# Altium schematic sheet MCU_AND_PW4.SchDoc
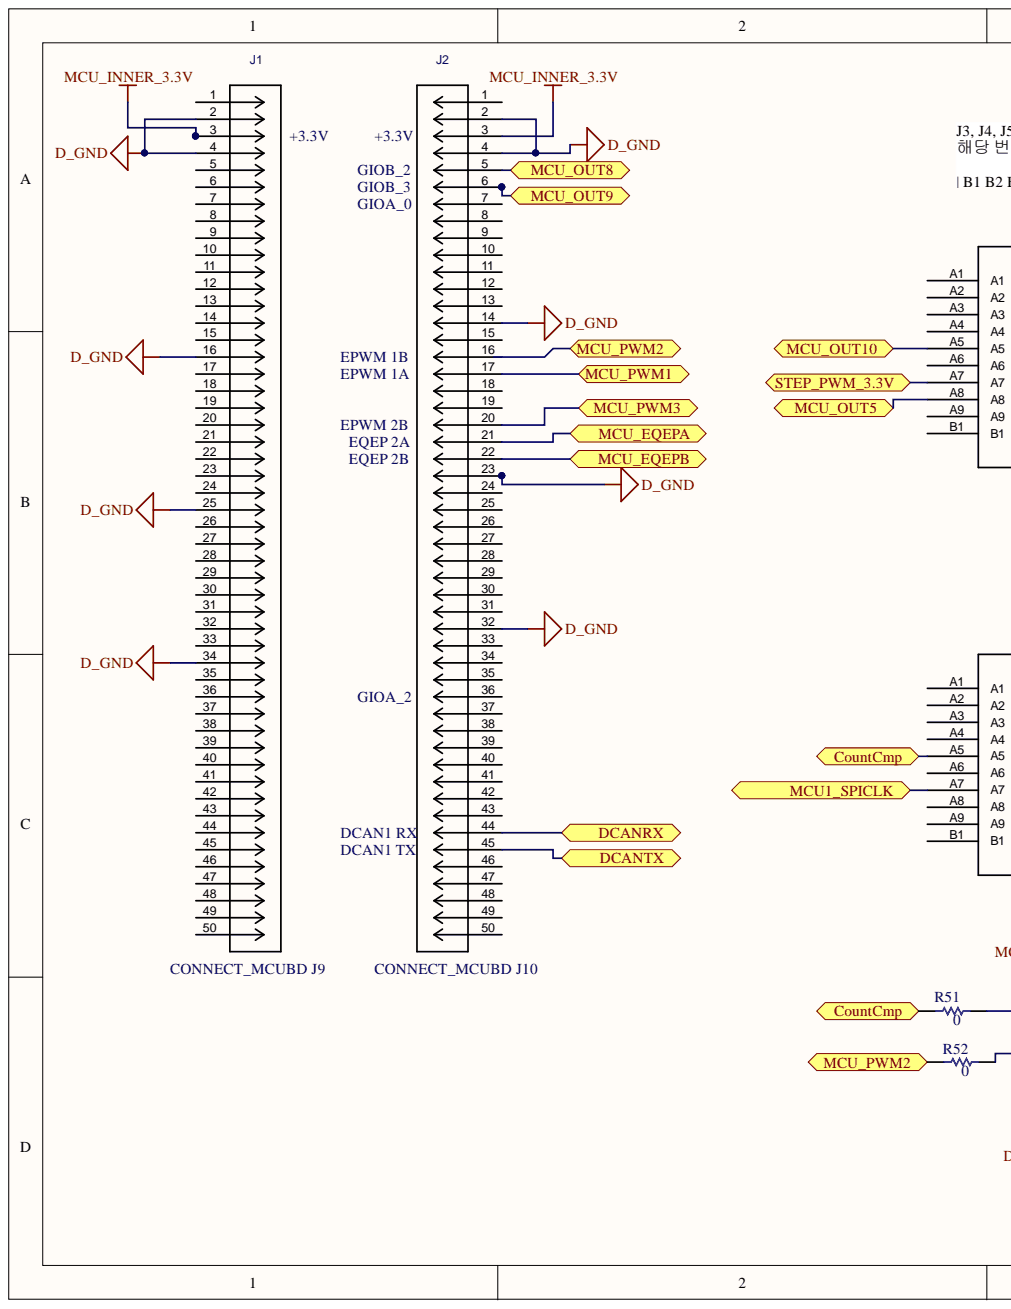
[diagram p0: MCU_AND_PW4.SchDoc, left]
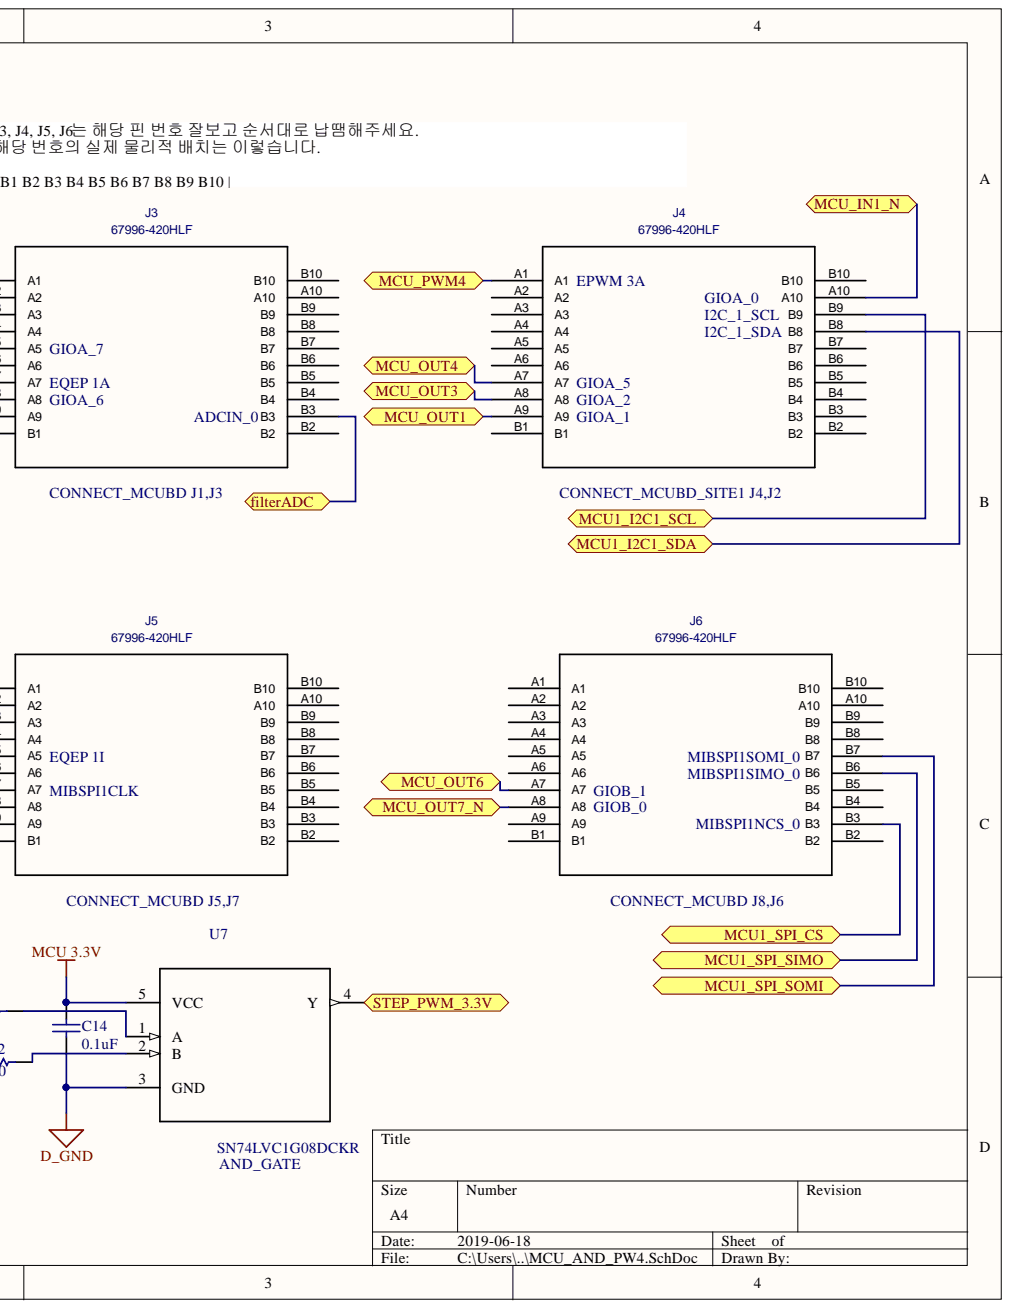
[diagram p1: MCU_AND_PW4.SchDoc, right]

## Parts
C14  value 0.1uF  [RAD-0.3]  (hidden comment: Cap)
J1  SLW-150-01-G-S  [CON50_1X50_TU_SL]  (value not drawn)
J2  SLW-150-01-G-S  [CON50_1X50_TU_SL]  (value not drawn)
J3  67996-420HLF  [67996-420HLF_67996-420HLF(Primary)]
J4  67996-420HLF  [67996-420HLF_67996-420HLF(Primary)]
J5  67996-420HLF  [67996-420HLF_67996-420HLF(Primary)]
J6  67996-420HLF  [67996-420HLF_67996-420HLF(Primary)]
R51  value 0  [AXIAL-0.3]  (hidden comment: Res1)
R52  value 0  [AXIAL-0.3]  (hidden comment: Res1)
U7  SN74LVC1G08DCKR  [*SOT65P210X110-5N]

## Ports
COUNTCMP, DCANRX, DCANTX, FILTERADC, MCU1_I2C1_SCL, MCU1_I2C1_SDA, MCU1_SPI_CS, MCU1_SPI_SIMO, MCU1_SPI_SOMI, MCU1_SPICLK, MCU_EQEPA, MCU_EQEPB, MCU_IN1_N, MCU_OUT1, MCU_OUT3, MCU_OUT4, MCU_OUT5, MCU_OUT6, MCU_OUT7_N, MCU_OUT8, MCU_OUT9, MCU_OUT10, MCU_PWM1, MCU_PWM2, MCU_PWM3, MCU_PWM4, STEP_PWM_3.3V

## Nets
D_GND: C14.2 J1.2 J1.4 J1.16 J2.23 U7.3(GND)
MCU 3.3V: C14.1 U7.5(VCC)
MCU_INNER_3.3V: J1.3
MCU1_I2C1_SCL: J4.B9 ; port MCU1_I2C1_SCL
MCU1_I2C1_SDA: J4.B8 ; port MCU1_I2C1_SDA
MCU1_SPI_CS: J6.B3 ; port MCU1_SPI_CS
MCU1_SPI_SIMO: J6.B6 ; port MCU1_SPI_SIMO
MCU1_SPI_SOMI: J6.B7 ; port MCU1_SPI_SOMI
MCU1_SPICLK: J5.A7 ; port MCU1_SPICLK
MCU_IN1_N: J4.A10 ; port MCU_IN1_N
MCU_OUT1: J4.A9 ; port MCU_OUT1
MCU_OUT3: J4.A8 ; port MCU_OUT3
MCU_OUT4: J4.A7 ; port MCU_OUT4
MCU_OUT5: J3.A8 ; port MCU_OUT5
MCU_OUT6: J6.A7 ; port MCU_OUT6
MCU_OUT7_N: J6.A8 ; port MCU_OUT7_N
MCU_OUT9: J2.6 ; port MCU_OUT9
MCU_OUT10: J3.A5 ; port MCU_OUT10
MCU_PWM2: R52.1 ; port MCU_PWM2
MCU_PWM4: J4.A1 ; port MCU_PWM4
STEP_PWM_3.3V: J3.A7 U7.4(Y) ; port STEP_PWM_3.3V
NetJ3_B3: J3.B3 ; port FILTERADC
NetJ5_A5: J5.A5 R51.1 ; port COUNTCMP
NetR51_2: R51.2 U7.1(A)
NetR52_2: R52.2 U7.2(B)
N00133: ; port MCU_PWM3
N00134: ; port MCU_PWM1
N00135: ; port MCU_EQEPB
N00136: ; port MCU_EQEPA
N00138: ; port DCANTX
N00139: ; port DCANRX
N00140: ; port MCU_OUT8

Not connected: J1 1 5 6 7 8 9 10 11 12 13 14 15 17 18 19 20 21 22 23 24 25 26 27 28 29 30 31 32 33 34 35 36 37 38 39 40 41 42 43 44 45 46 47 48 49 50; J2 1 2 3 4 5 7 8 9 10 11 12 13 14 15 16 17 18 19 20 21 22 24 25 26 27 28 29 30 31 32 33 34 35 36 37 38 39 40 41 42 43 44 45 46 47 48 49 50; J3 A1 A2 A3 A4 A6 A9 A10 B1 B2 B4 B5 B6 B7 B8 B9 B10; J4 A2 A3 A4 A5 A6 B1 B2 B3 B4 B5 B6 B7 B10; J5 A1 A2 A3 A4 A6 A8 A9 A10 B1 B2 B3 B4 B5 B6 B7 B8 B9 B10; J6 A1 A2 A3 A4 A5 A6 A9 A10 B1 B2 B4 B5 B8 B9 B10

## Library data of the parts
C14 = Cap: Capacitor; Component Kind: Standard; LatestRevisionDate: 17-Jul-2002; LatestRevisionNote: Re-released for DXP Platform.; Library Name: Miscellaneous Devices.IntLib; PackageDescription: Radial Cap, Thru-Hole; 2 Leads; 0.3 in Pin Spacing; PackageReference: RAD-0.3; Published: 8-Jun-2000; Signal Integrity: Cap; Simulation: CAP; Publisher: Altium Limited
J1 J2 = SLW-150-01-G-S: Built_By: EMA_UL_Team; C: Copyright (C) 2015 Accelerated Designs. All rights reserved; Vendor: Samtec Inc; Component Kind: Standard; Library Name: 50pinF.SchLib; Manufacturer_Part_Number: SLW-150-01-G-S; Value: SLW-150-01-G-S
J3-J6 = 67996-420HLF: Built_By: EMA_UL_Team; C: Copyright (C) 2015 Accelerated Designs. All rights reserved; Vendor: Amphenol FCI; Component Kind: Standard; Library Name: 2X10pinM.SchLib; Manufacturer_Part_Number: 67996-420HLF; Value: 67996-420HLF
R51 R52 = Res1: Resistor; Component Kind: Standard; LatestRevisionDate: 17-Jul-2002; LatestRevisionNote: Re-released for DXP Platform.; Library Name: Miscellaneous Devices.IntLib; PackageDescription: Axial Device, Thru-Hole; 2 Leads; 0.3 in Pin Spacing; PackageReference: AXIAL-0.3; Published: 8-Jun-2000; Signal Integrity: Res; Simulation: RESISTOR; Publisher: Altium Limited
U7 = SN74LVC1G08DCKR: Single 2-Input Positive-AND Gate 5-SC70 -40 to 125; MF: Texas Instruments; Availability: Unavailable; Component Kind: Standard; Library Name: SN74LVC1G08DCKR.IntLib; MP: SN74LVC1G08DCKR; Package: SC-70-5 Texas Instruments; Price: None; SnapEDA_Link: https://www.snapeda.com/parts/SN74LVC1G08DCKR/Texas%20Instruments/view-part/?ref=snap
